# Altium schematic sheet PFM_powerManagement_externalBattery.SchDoc(PFM_powerManagement_externalBattery)
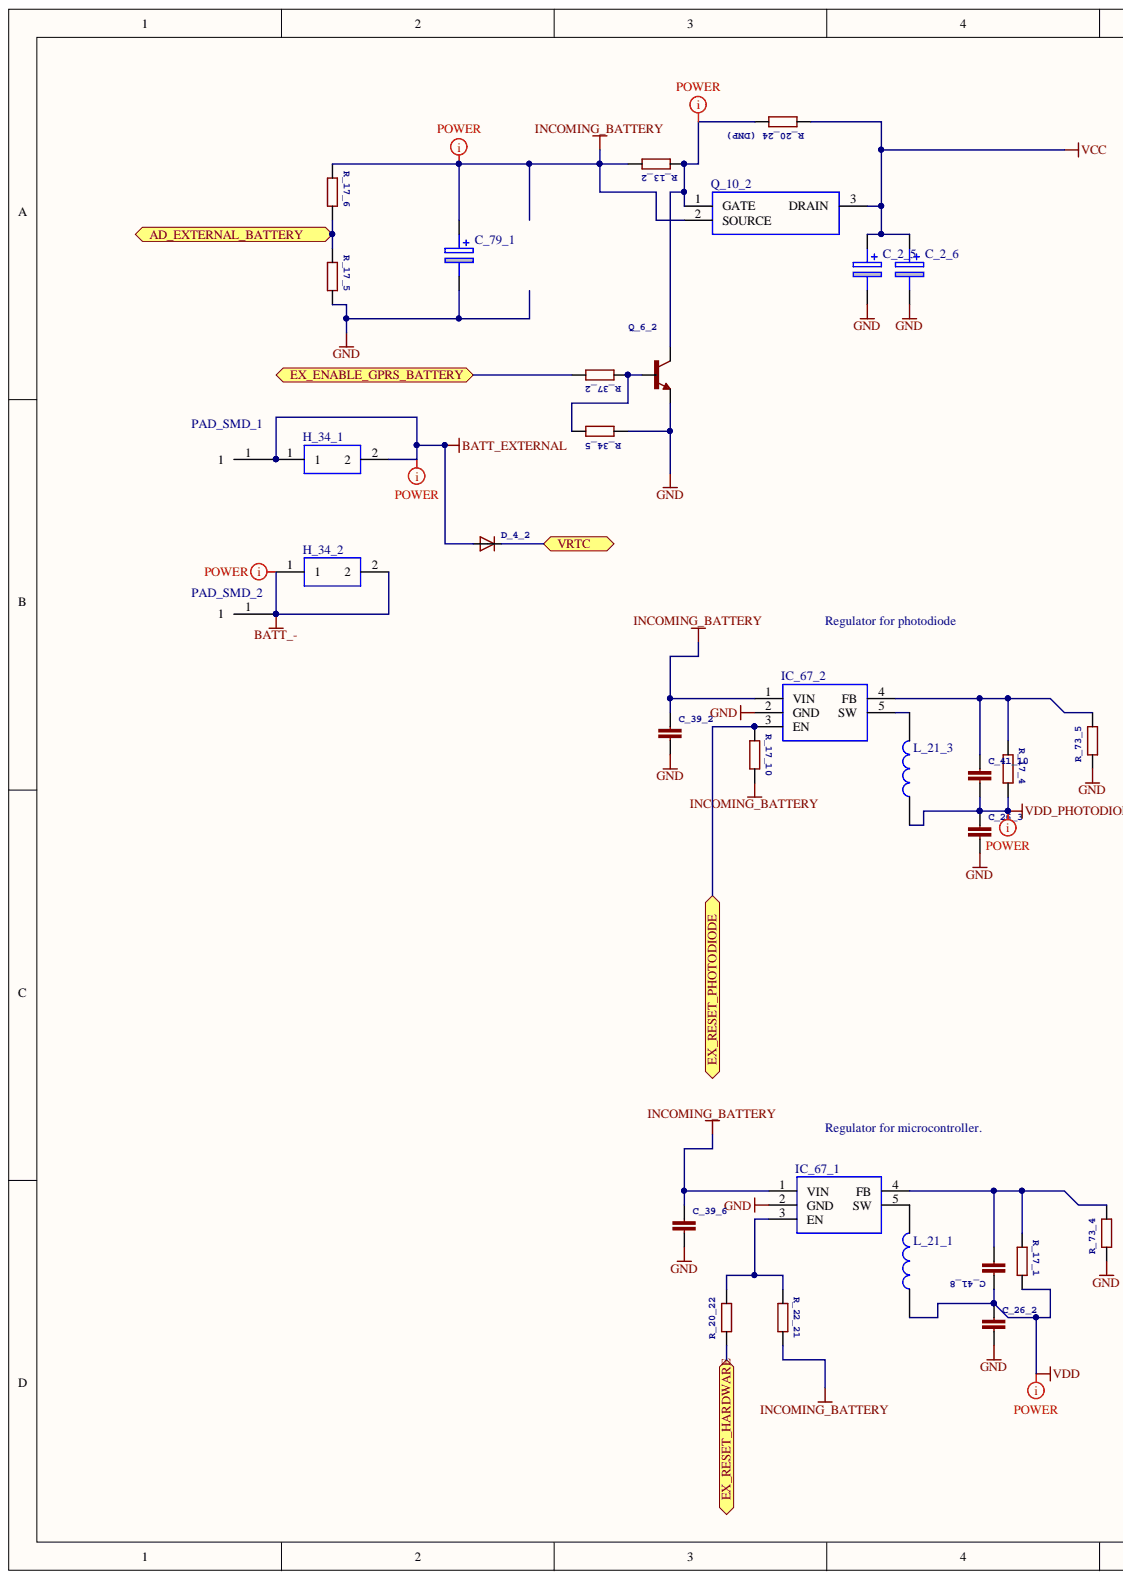
[diagram p0: PFM_powerManagement_externalBattery.SchDoc, left]
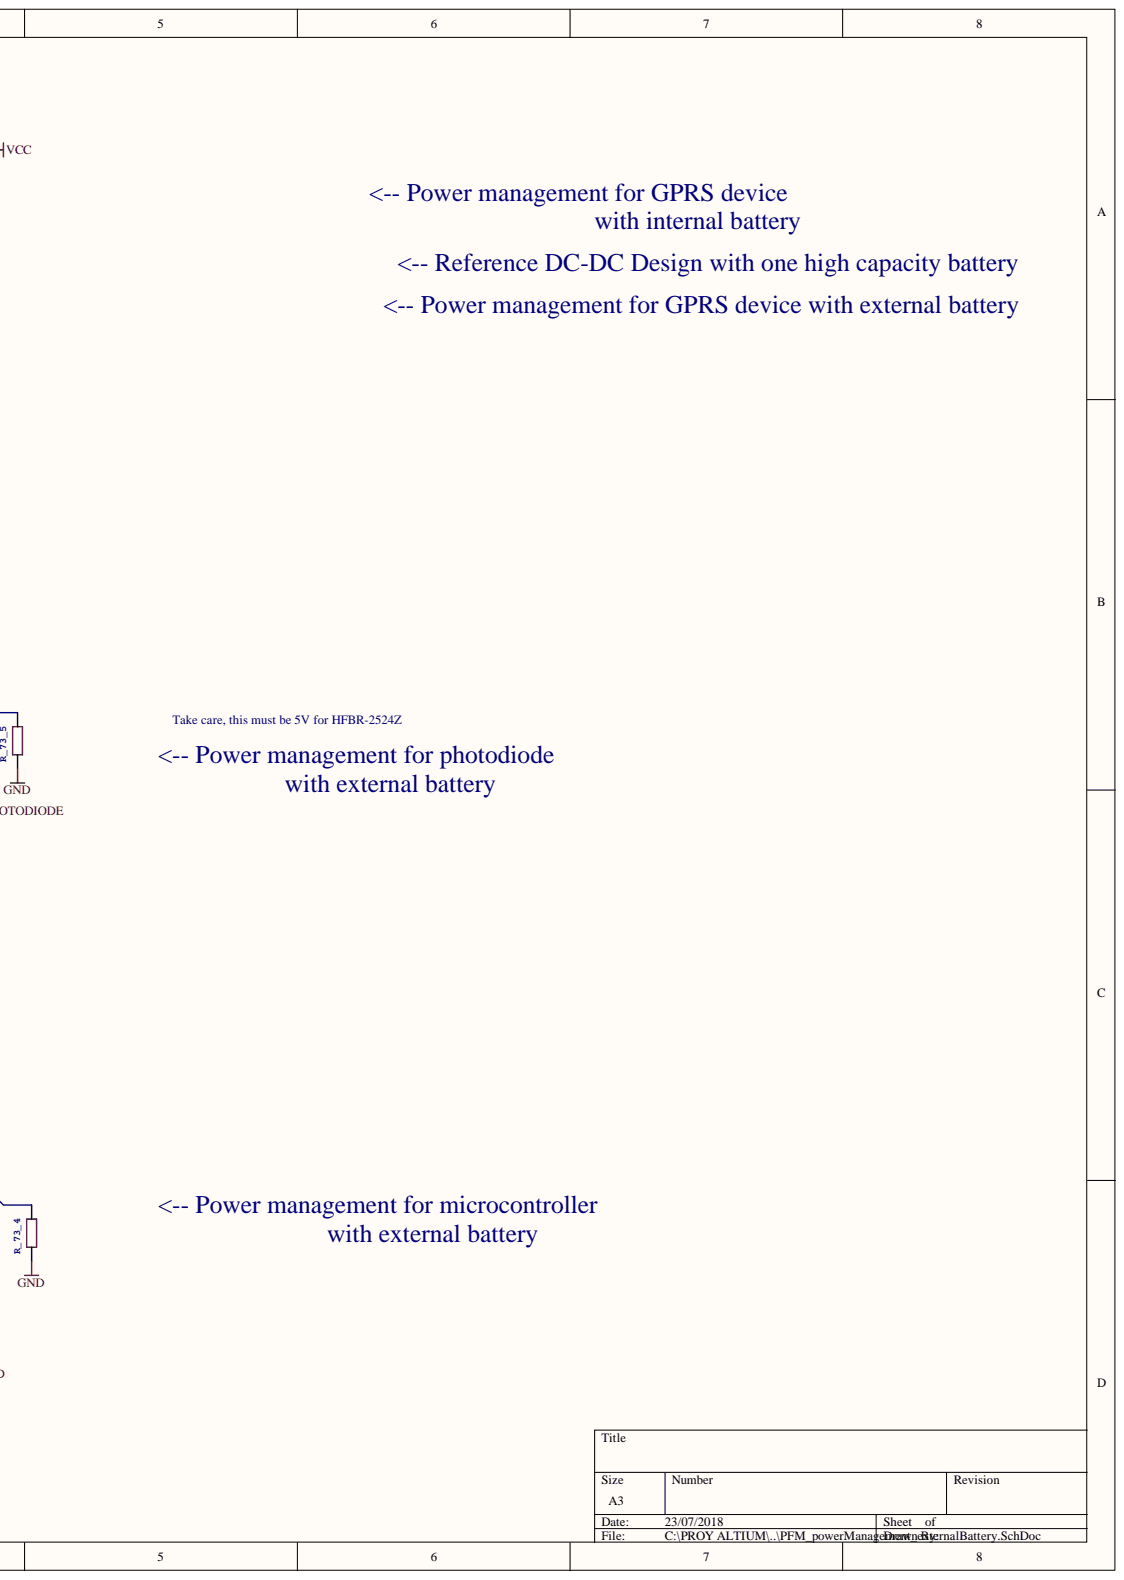
[diagram p1: PFM_powerManagement_externalBattery.SchDoc, right]

## Parts
C_2_5  470uF;TPSE477K010R0200;AVX  [CAPPC7344X310N]  (value not drawn)
C_2_6  470uF;TPSE477K010R0200;AVX  [CAPPC7344X310N]  (value not drawn)
C_26_2  10uF;0603X106M6R3CT;Walsin  [C0603K]  (value not drawn)
C_26_3  10uF;0603X106M6R3CT;Walsin  [C0603K]  (value not drawn)
C_39_2  4.7Uf;CL10A475KO8NNNC;Samsung;  [C0603K]  (value not drawn)
C_39_6  4.7Uf;CL10A475KO8NNNC;Samsung;  [C0603K]  (value not drawn)
C_41_8  33pF;C0603C330J5GACTU;Kemet  [C0603K]  (value not drawn)
C_41_10  33pF;C0603C330J5GACTU;Kemet  [C0603K]  (value not drawn)
C_79_1  2200uF;URY0J222MHD;Nichicon  [CAPPRD500W10D1300H2200]  (value not drawn)
D_4_2  B360A-13-F;Diodes;  [DO214AC]  (value not drawn)
H_34_1  1217080-1;TE connectivity  [1217080-1]  (value not drawn)
H_34_2  1217080-1;TE connectivity  [1217080-1]  (value not drawn)
IC_67_1  TPS62240DDCRG4;Texas  [SOT95P280X110-5N]  (value not drawn)
IC_67_2  TPS62240DDCRG4;Texas  [SOT95P280X110-5N]  (value not drawn)
L_21_1  2.2uH;LQH43PN2R2M26L;Murata  [LQH43PN2R2M26L]  (value not drawn)
L_21_3  2.2uH;LQH43PN2R2M26L;Murata  [LQH43PN2R2M26L]  (value not drawn)
PAD_SMD_1  PAD  [PAD]
PAD_SMD_2  PAD  [PAD]
Q_6_2  BC847MTBF;Fairchild  [SOT23]  (value not drawn)
Q_10_2  DMP2120U;Diodes  [SOT96P240X100-3N]  (value not drawn)
R_13_2  100k;ERJ-3GEYJ104V;Panasonic;  [R0603]  (value not drawn)
R_17_1  360k;MCHV06W8J0364T5E;Multicomp  [R0603]  (value not drawn)
R_17_4  360k;MCHV06W8J0364T5E;Multicomp  [R0603]  (value not drawn)
R_17_5  360k;MCHV06W8J0364T5E;Multicomp;  [R0603]  (value not drawn)
R_17_6  360k;MCHV06W8J0364T5E;Multicomp  [R0603]  (value not drawn)
R_17_10  360k;MCHV06W8J0364T5E;Multicomp  [R0603]  (value not drawn)
R_20_22  0;ERJ3GEY0R00V;Panasonic;  [R0603]  (value not drawn)
R_20_24_(DNP)  0;ERJ3GEY0R00V;Panasonic;  [R0603]  (value not drawn)
R_22_21  10k;MCR03ERTJ103;ROHM SEMICONDUCTOR  [R0603]  (value not drawn)
R_34_5  47k;RC0603JR-0747KL;Yageo  [R0603]  (value not drawn)
R_37_2  4k7;RC0603JR-074K7L;Yageo  [R0603]  (value not drawn)
R_73_4  80k;PTN0603E8002BST1;Vishay  [R0603]  (value not drawn)
R_73_5  80k;PTN0603E8002BST1;Vishay  [R0603]  (value not drawn)

## Ports
AD_EXTERNAL_BATTERY, EX_ENABLE_GPRS_BATTERY, EX_RESET_HARDWARE, EX_RESET_PHOTODIODE, VRTC

## Nets
BATT_-: H_34_2.1 H_34_2.2 PAD_SMD_2.1
BATT_EXTERNAL: D_4_2.A H_34_1.1 H_34_1.2 PAD_SMD_1.1
GND: C_2_5.2 C_2_6.2 C_26_2.2 C_26_3.2 C_39_2.2 C_39_6.2 C_79_1.2 IC_67_1.2(GND) IC_67_2.2(GND) Q_6_2.2 R_17_5.2 R_34_5.1 R_73_4.1 R_73_5.1
INCOMING_BATTERY: C_39_2.1 C_39_6.1 C_79_1.1 IC_67_1.1(VIN) IC_67_2.1(VIN) Q_10_2.2 R_13_2.2 R_17_6.1 R_17_10.2 R_22_21.2
VCC: C_2_5.1 C_2_6.1 Q_10_2.3 R_20_24_(DNP).1
VDD: C_26_2.1 C_41_8.1 L_21_1.1 R_17_1.2
VDD_PHOTODIODE: C_26_3.1 C_41_10.2 L_21_3.1 R_17_4.2
AD_EXTERNAL_BATTERY: R_17_5.1 R_17_6.2 ; port AD_EXTERNAL_BATTERY
EX_ENABLE_GPRS_BATTERY: R_37_2.2 ; port EX_ENABLE_GPRS_BATTERY
EX_RESET_HARDWARE: R_20_22.1 ; port EX_RESET_HARDWARE
EX_RESET_PHOTODIODE: IC_67_2.3(EN) R_17_10.1 ; port EX_RESET_PHOTODIODE
VRTC: D_4_2.C ; port VRTC
NetC_41_8_2: C_41_8.2 IC_67_1.4(FB) R_17_1.1 R_73_4.2
NetC_41_10_1: C_41_10.1 IC_67_2.4(FB) R_17_4.1 R_73_5.2
NetIC_67_1_3: IC_67_1.3(EN) R_20_22.2 R_22_21.1
NetIC_67_1_5: IC_67_1.5(SW) L_21_1.2
NetIC_67_2_5: IC_67_2.5(SW) L_21_3.2
NetQ_6_2_1: Q_6_2.1 R_34_5.2 R_37_2.1
NetQ_6_2_3: Q_6_2.3 Q_10_2.1 R_13_2.1 R_20_24_(DNP).2

## Library data of the parts
C_2_5 C_2_6 = TPSE477K010R0200: AVX 470F SMD Solid MnO2 Tantalum Electrolytic Capacitor, 10 V dc 10%, TPS Series; Geometry.Height: 3.1mm; Manufacturer_Part_Number: TPSE477K010R0200; Manufacturer_Name: AVX; Library Name: TPSE477K010R0200.SchLib; Datasheet Link: http://uk.rs-online.com/web/p/products/6993747P; Component Kind: Standard; Arrow Part Number: TPSE477K010R0200
C_26_2 C_26_3 = C-EUC0603K: 10uF; Component Kind: Standard; Library Name: Easynet_Commboard_v1p1.IntLib
C_39_2 C_39_6 = C-EUC0603K: 4.7uF;CL10A475KO8NNNC;Samsung; Component Kind: Standard; Library Name: Easynet_Commboard_v1p1.IntLib
C_41_8 C_41_10 = C-EUC0603K: Component Kind: Standard; Library Name: Easynet_Commboard_v1p1.IntLib
C_79_1 = ESL228M016AK5AA: Aluminum Electrolytic Capacitors - Leaded 16V 2200uF 20%; Manufacturer_Name: Kemet; Geometry.Height: 22mm; Manufacturer_Part_Number: ESL228M016AK5AA; Library Name: ESL228M016AK5AA.SchLib; Datasheet Link: https://componentsearchengine.com/Datasheets/1/ESL228M016AK5AA.pdf; Component Kind: Standard; Arrow Part Number: ESL228M016AK5AA
D_4_2 = DIODE-DO214AC: Component Kind: Standard; Library Name: Easynet_Commboard_v1p1.IntLib
H_34_1 H_34_2 = 1217080-1: Receptacle terminal, PCB, FASTON 205/250 TE Connectivity FASTON .205/.250 PCB Terminal, Female, Crimp, Tin Plating; RS Price/Stock: http://uk.rs-online.com/web/p/products/7188116P; RS Part Number: 7188116P; Manufacturer_Part_Number: 1217080-1; Manufacturer_Name: TE Connectivity; Library Name: 1217080-1.SchLib; Datasheet Link: http://www.te.com/commerce/DocumentDelivery/DDEController?Action=srchrtrv&DocNm=1217080&DocType=Customer+Drawing&DocLang=English; Component Kind: Standard; Arrow Price/Stock: https://www.arrow.com/en/products/1217080-1/te-connectivity; Arrow Part Number: 1217080-1
IC_67_1 IC_67_2 = TPS62240DDCRG4: Switching Voltage Regulators 2.25MHz 300mA Step- Down Converter; Manufacturer_Name: Texas Instruments; Geometry.Height: 1.1mm; Manufacturer_Part_Number: TPS62240DDCRG4; Library Name: TPS62240DDCRG4.SchLib; Datasheet Link: http://www.ti.com/lit/ds/symlink/tps62240.pdf; Component Kind: Standard; Arrow Part Number: TPS62240DDCRG4
L_21_1 L_21_3 = LQH43PN2R2M26L: Murata LQH43PN_26 Series Shielded Wire-wound SMD Inductor with a Ferrite Core, 2.2 H Wire-Wound 2.3A Idc; Manufacturer_Name: Murata Electronics; RS Price/Stock: http://uk.rs-online.com/web/p/products/1065329P; RS Part Number: 1065329P; Manufacturer_Part_Number: LQH43PN2R2M26L; Library Name: LQH43PN2R2M26L.SchLib; Datasheet Link: https://componentsearchengine.com/Datasheets/2/LQH43PN2R2M26L.pdf; Component Kind: Standard; Arrow Price/Stock: https://www.arrow.com/en/products/lqh43pn2r2m26l/murata-manufacturing; Arrow Part Number: LQH43PN2R2M26L
Q_6_2 = BC847*SMD: Component Kind: Standard; Library Name: Easynet_Commboard_v1p1.IntLib
Q_10_2 = DMP2160U-7: Diodes Inc DMP2160U-7 P-channel MOSFET Transistor, 3.3 A, -20 V, 3-Pin SOT-23; Manufacturer_Name: Diodes Inc.; Geometry.Height: 1mm; RS Price/Stock: http://uk.rs-online.com/web/p/products/7514247P; RS Part Number: 7514247P; Manufacturer_Part_Number: DMP2160U-7; Library Name: DMP2160U-7.SchLib; Datasheet Link: https://componentsearchengine.com/Datasheets/2/DMP2160U-7.pdf; Component Kind: Standard; Arrow Price/Stock: https://www.arrow.com/en/products/dmp2160u-7/diodes-incorporated; Arrow Part Number: DMP2160U-7
R_13_2 R_17_1 R_17_4-R_17_6 R_17_10 R_20_22 R_20_24_(DNP) R_22_21 R_34_5 R_37_2 R_73_4 R_73_5 = R-EU_R0603: RESISTOR, European symbol; Library Name: PBv4p0.SCHLIB; Component Kind: Standard
PAD_SMD_1 PAD_SMD_2 = PAD: Component Kind: Standard; Library Name: PFM_SA_v1p0.SCHLIB
